# Altium schematic sheet 03_Power_Delivery.SchDoc
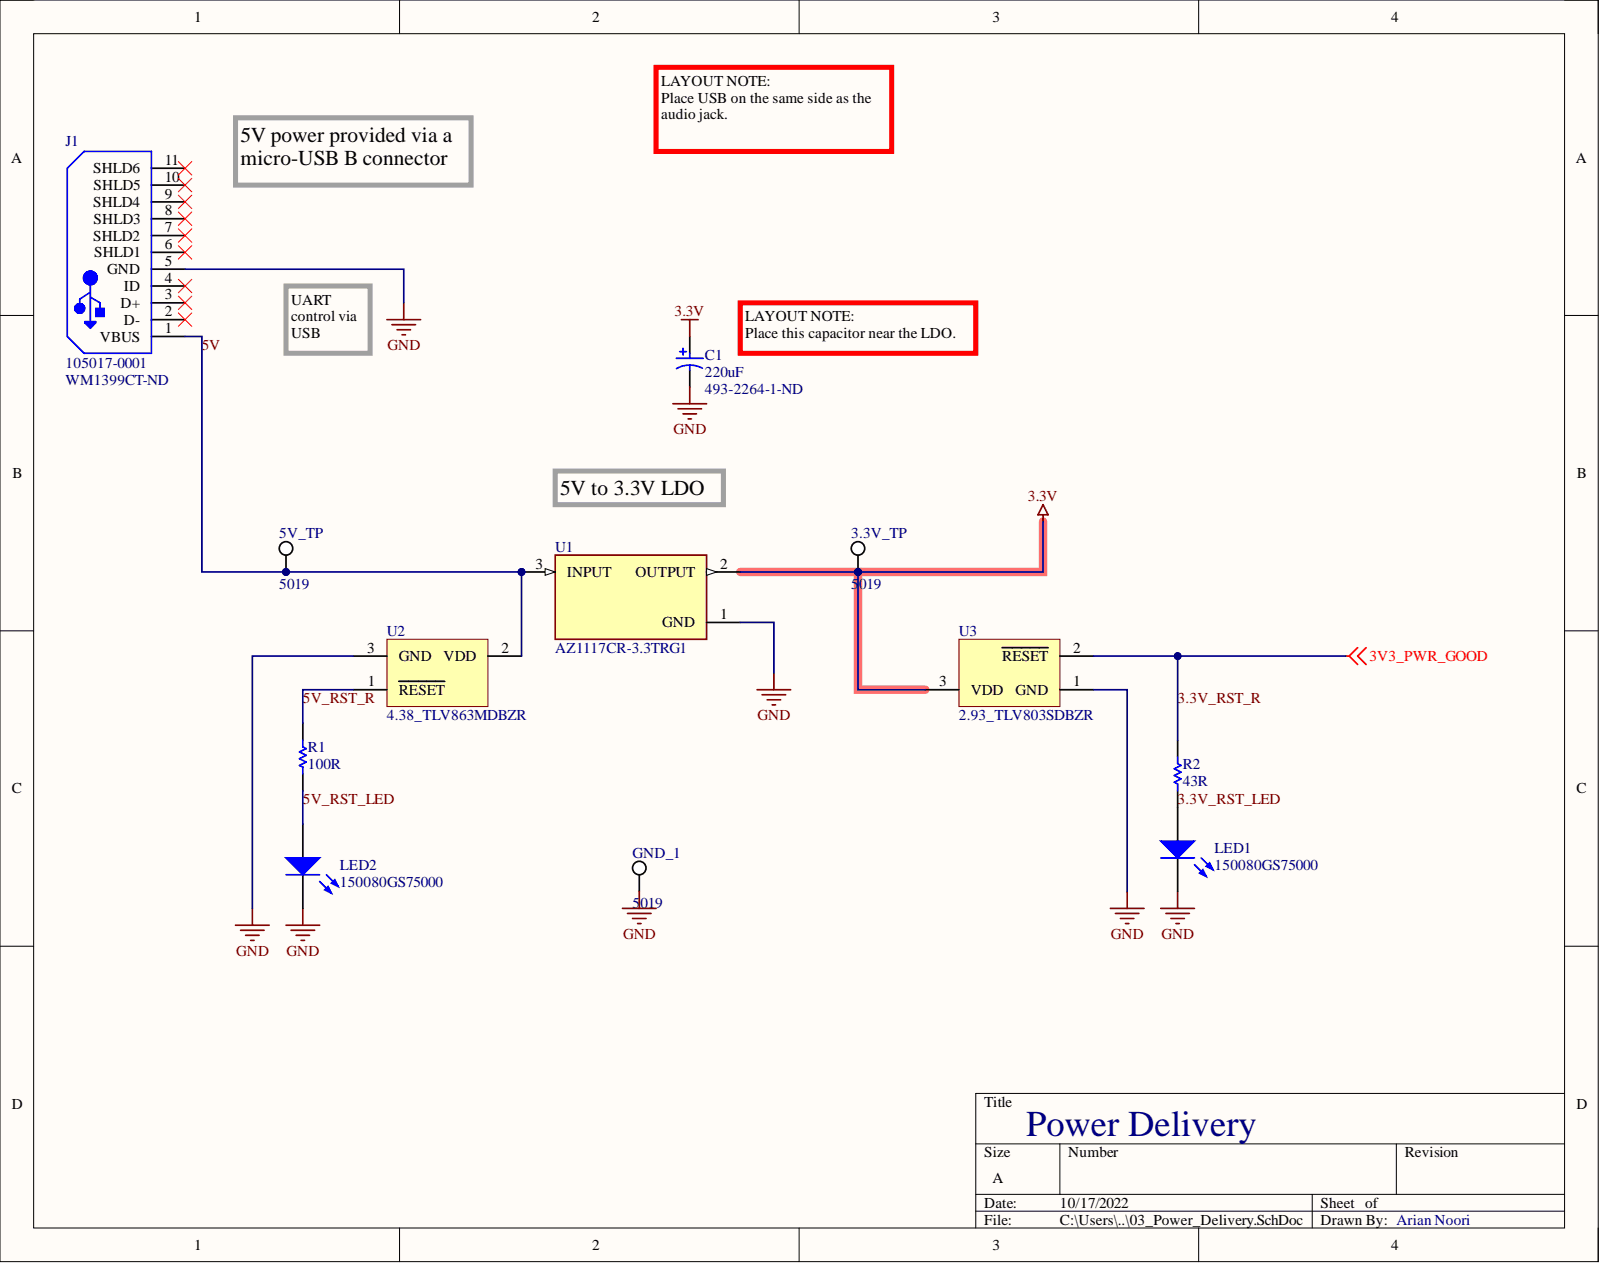
[diagram p0: 03_Power_Delivery.SchDoc]

## Parts
3.3V_TP  5019  [KSTN-5019_V]
5V_TP  5019  [KSTN-5019_V]
C1  220uF  Supplier Part Number 1: 493-2264-1-ND  [NIC-CAPA2-6.3X7.7_V]
GND_1  5019  [KSTN-5019_V]
J1  105017-0001  Supplier Part Number 1: WM1399CT-ND  [USB-MICRO-B_V]
LED1  150080GS75000  [0805_A]
LED2  150080GS75000  [0805_A]
R1  100R  [RESC2013X60X35ML10T20]
R2  43R  [RESC2013X60X35LL10T20]
U1  AZ1117CR-3.3TRG1  [FP-SOT89-MFG]
U2  4.38_TLV863MDBZR  [DBZ0003A_N]
U3  2.93_TLV803SDBZR  [DBZ0003A_M]

## Nets
3.3V: 3.3V_TP.1 C1.1 U1.2 U3.3
GND: C1.2 GND_1.1 J1.5(GND) LED1.1 LED2.1 U1.1 U2.3 U3.1
3.3V_RST_LED: LED1.2 R2.1
3.3V_RST_R: R2.2 U3.2
5V: 5V_TP.1 J1.1(VBUS) U1.3 U2.2
5V_RST_LED: LED2.2 R1.1
5V_RST_R: R1.2 U2.1

Not connected: J1 2(D-) 3(D+) 4(ID) 6(SHLD1) 7(SHLD2) 8(SHLD3) 9(SHLD4) 10(SHLD5) 11(SHLD6)

## Library data of the parts
3.3V_TP 5V_TP GND_1 = CMP-1672-00008-1: Test Point, 1 Position SMD, RoHS, Tape and Reel; Manufacturer: Keystone Electronics; Category: Connectors; Component Kind: Standard; ComponentLink1Description: Manufacturer URL; ComponentLink1URL: http://www.keyelco.com/; ComponentLink2Description: Datasheet; ComponentLink2URL: http://www.keyelco.com/product-pdf.cfm?p=1357; ComponentLink3Description: https://datasheet.ciiva.com/16318/m60-2p61-16318395.pdf?src-supplier=Future+Electronics; ComponentLink3URL: https://datasheet.ciiva.com/16318/m60-2p61-16318395.pdf?src-supplier=Future+Electronics; ComponentLink4Description: https://datasheet.ciiva.com/16885/70182998-16885945.pdf?src-supplier=Allied+Electronics; ComponentLink4URL: https://datasheet.ciiva.com/16885/70182998-16885945.pdf?src-supplier=Allied+Electronics; ComponentLink5Description: https://datasheet.ciiva.com/14848/m60-2p61-14848437.pdf?src-supplier=Digi-Key; ComponentLink5URL: https://datasheet.ciiva.com/14848/m60-2p61-14848437.pdf?src-supplier=Digi-Key; ComponentLink6Description: https://datasheet.ciiva.com/14848/m60-2p61-14848438.pdf?src-supplier=Digi-Key; ComponentLink6URL: https://datasheet.ciiva.com/14848/m60-2p61-14848438.pdf?src-supplier=Digi-Key; ComponentLink7Description: https://datasheet.ciiva.com/14848/m60-2p61-14848439.pdf?src-supplier=Digi-Key; ComponentLink7URL: https://datasheet.ciiva.com/14848/m60-2p61-14848439.pdf?src-supplier=Digi-Key; Contact Material: Bronze; Contact Plating: Silver; DatasheetVersion: 03/2014; Height: 0.09inch; Lead Free: Lead Free; Library Name: Altium Content Vault; Manufacturer Part Number: 5019; Material: Bronze; Mount: Surface Mount; Mounting Technology: Surface Mount; Number of Pins: 1; Package Quantity: 1000; PackageDescription: 1-Pin Surface Mount Device, Body 3.81 x 2.03 mm; PackageReference: 5019; Packaging: Tape and Reel; Pins: 1; Pricing 1: 1=0.34000, 25=0.27360, 100=0.22340, 250=0.20064, 500=0.19152 (USD); REACH SVHC: No SVHC; RoHS: Yes; RoHS Compliant: Yes; RoHSCompliant: True; Series: 5019; Stock 1: 1306897; Supplier 1: Digi-Key; Termination: SMD/SMT
C1 = CMP-0687-00060-1: Aluminum Electrolytic Capacitor, 220uF 16 V, UD Series 2000h 6.3 (Dia.) x 7.7mm; Manufacturer: Nichicon; Manufacturer Part Number: UUD1C221MCL1GS; Dielectric Material: Aluminium; Dissipation Factor: 0.2%; Impedance: 0.34 Ohm; Lead Diameter: 0.8mm; Leakage Current: 3uA; Life (Hours): 2000Hour; Packing: Dia382mm Reel; Rated Ripple Current (at 100kHz): 280 mA; Rated Voltage: 16 V; Ripple Current: 280mA; Tolerance: 20%; Tolerance Rated Capacitance: -20 to 20%; Voltage: 16V; Capacitance: 220uF; Case/Package: Radial; Category: Aluminum Electrolytic Capacitors; Component Kind: Standard; ComponentLink1Description: Manufacturer URL; ComponentLink1URL: http://www.nichicon.co.jp/; ComponentLink2Description: Datasheet; ComponentLink2URL: http://products.nichicon.co.jp/en/pdf/XJA043/e-ud.pdf; ComponentLink3Description: Package Specification; ComponentLink3URL: http://products.nichicon.co.jp/en/pdf/XJA043/e-ud.pdf; ComponentLink4Description: https://datasheet.ciiva.com/97/e-ud-97205.pdf?src-supplier=Digi-Key; ComponentLink4URL: https://datasheet.ciiva.com/97/e-ud-97205.pdf?src-supplier=Digi-Key; ComponentLink5Description: https://datasheet.ciiva.com/97/e-ud-97206.pdf?src-supplier=Digi-Key; ComponentLink5URL: https://datasheet.ciiva.com/97/e-ud-97206.pdf?src-supplier=Digi-Key; ComponentLink6Description: https://datasheet.ciiva.com/97/e-ud-97207.pdf?src-supplier=Digi-Key; ComponentLink6URL: https://datasheet.ciiva.com/97/e-ud-97207.pdf?src-supplier=Digi-Key; ComponentLink7Description: https://datasheet.ciiva.com/11685/e-ud-11685974.pdf?src-supplier=Avnet; ComponentLink7URL: https://datasheet.ciiva.com/11685/e-ud-11685974.pdf?src-supplier=Avnet; ComponentLink8Description: https://datasheet.ciiva.com/5361/e-ud-5361934.pdf?src-supplier=Mouser; ComponentLink8URL: https://datasheet.ciiva.com/5361/e-ud-5361934.pdf?src-supplier=Mouser; ComponentLink9Description: https://datasheet.ciiva.com/16373/e-ud-16373647.pdf?src-supplier=Future+Electronics; ComponentLink9URL: https://datasheet.ciiva.com/16373/e-ud-16373647.pdf?src-supplier=Future+Electronics; ComponentLink10Description: https://datasheet.ciiva.com/7781/e-ud-7781693.pdf?src-supplier=Element14; ComponentLink10URL: https://datasheet.ciiva.com/7781/e-ud-7781693.pdf?src-supplier=Element14; Construction: Cylindrical; DatasheetVersion: 08/2012; Diameter: 6.3 mm; Endurance Time: 2000 h; Height: 7.7mm; Height - Seated (Max): 0.303inch; Lead Free: Lead Free; Lead Pitch: 2.2mm; Length: 7.7 mm; Library Name: Altium Content Vault; Max Operating Temperature: 105°C; Min Operating Temperature: -55°C; Mounting Technology: Surface Mount; Number of Pins: 2; Package Quantity: 1; PackageDescription: 2-Pin Surface Mount Device, Diameter 6.3 mm, Height 7.7 mm, Pitch 5.4 mm; PackageReference: CAPAE-D6.3H7.7; Packaging: Tape and Reel; PartNumber: UUD1C221MCL1GS; Pricing 1: 1=0.46000, 10=0.32000, 50=0.22420, 100=0.19220 (USD); Radiation Hardening: No; Rated Capacitance: 220 uF; REACH SVHC: No SVHC; Ripple Current (AC): 280mA; RoHS: TRUE; RoHS Compliant: Yes; Series: UD; Stock 1: 25833; Supplier 1: Digi-Key; Termination: SMD/SMT; Voltage Rating: 16V; Voltage Rating (DC): 16V
J1 = CMP-2000-05827-1: Micro-USB B Receptacle, Right Angle, Bottom Mount, Surface Mount, with Solder Tabs, -30 to 85 degC, 5-Pin USB, RoHS, Tape and Reel; Manufacturer: Molex; 3D Model: 105017-0001.stp; Catalog Drawings: 1050170001; Circuits: 5; Connector Type: USB-microB; Current Rating: 1.8A; Featured Product: Micro-USBConnectorsUSBConnectors; Mating Cycles: 10000; Mating Products: WM17145-ND-CABLEMICROUSBBTOSTDA1.0MWM17147-ND-CABLEMICROUSBBTOSTDA2.0MWM17146-ND-CABLEMICROUSBBTOSTDA1.5M; Max Voltage Rating (AC): 30V; Number of Ports: 1; Online Catalog: Molex-MicroUSB; Other Names: WM1399DKR; Package Version: Rev. F, 12/2012; PCN Assembly/Origin: ResinSupplier21/Oct/2015; Pitch: 0.65mm; Shielding: Shielded; USB Standard: USB 2.0; Category: Connectors,Interconnects; Component Kind: Standard; ComponentLink1Description: https://datasheet.ciiva.com/30165/235271050170001-io-nectors-30165183.pdf?src-supplier=Onlinecomponents.com; ComponentLink1URL: https://datasheet.ciiva.com/30165/235271050170001-io-nectors-30165183.pdf?src-supplier=Onlinecomponents.com; ComponentLink2Description: https://datasheet.ciiva.com/8015/1631959-8015288.pdf?src-supplier=Element14; ComponentLink2URL: https://datasheet.ciiva.com/8015/1631959-8015288.pdf?src-supplier=Element14; ComponentLink3Description: https://datasheet.ciiva.com/22654/1688140-22654924.pdf?src-supplier=Element14; ComponentLink3URL: https://datasheet.ciiva.com/22654/1688140-22654924.pdf?src-supplier=Element14; ComponentLink4Description: https://datasheet.ciiva.com/3094/1050170001-io-connectors-3094416.pdf?src-supplier=Avnet; ComponentLink4URL: https://datasheet.ciiva.com/3094/1050170001-io-connectors-3094416.pdf?src-supplier=Avnet; ComponentLink5Description: https://datasheet.ciiva.com/4598/1050170001-io-connectors-152207-4598759.pdf?src-supplier=Mouser; ComponentLink5URL: https://datasheet.ciiva.com/4598/1050170001-io-connectors-152207-4598759.pdf?src-supplier=Mouser; ComponentLink6Description: https://datasheet.ciiva.com/851/1050170001-sd-851276.pdf?src-supplier=Digi-Key; ComponentLink6URL: https://datasheet.ciiva.com/851/1050170001-sd-851276.pdf?src-supplier=Digi-Key; ComponentLink7Description: https://datasheet.ciiva.com/851/1050170001-sd-851277.pdf?src-supplier=Digi-Key; ComponentLink7URL: https://datasheet.ciiva.com/851/1050170001-sd-851277.pdf?src-supplier=Digi-Key; ComponentLink8Description: https://datasheet.ciiva.com/851/1050170001-sd-851278.pdf?src-supplier=Digi-Key; ComponentLink8URL: https://datasheet.ciiva.com/851/1050170001-sd-851278.pdf?src-supplier=Digi-Key; ComponentLink9Description: https://datasheet.ciiva.com/16668/1050170001-io-connectors-16668598.pdf?; ComponentLink9URL: https://datasheet.ciiva.com/16668/1050170001-io-connectors-16668598.pdf?; Contact Material: Copper Alloy,Copper; Contact Plating: Gold; Datasheet URL: http://www.molex.com/elqNow/elqRedir.htm?ref=http://www.molex.com/webdocs/datasheets/pdf/en-us/1050170001_IO_CONNECTORS.pdf; Datasheet Version: 03/2016; Depth: 7.5mm; Family: USB,DVI,HDMIConnectors; Features: Shielded,SolderRetention; Flammability Rating: UL94 V-0; Gender: Receptacle; Halogen Free: Low Halogen; Housing Material: Polymer; Ingress Protection: -; Insulation Color: Black; Length: 8.2mm; Library Name: Altium Content Vault; Manufacturer Part Number: 1050170001; Manufacturer URL: http://www.molex.com/; Max Operating Temperature: 85°C; Min Operating Temperature: -30°C; Mount: Surface Mount,Through Hole,Horizontal; Mounting Technology: SurfaceMount; Mounting Type: BoardCutout,SurfaceMount,RightAngle; Number of Circuits: 5; Number of Contacts: 5; Number of Positions: 5; Number of Terminals/Pins: 5; Orientation: Right Angle; Package Description: 5-Leads USB, Micro B, Body 7.5 x 5 mm, Pitch 0.65 mm; Package Quantity: 2000; Package Reference: MICRO-B; Package URL: http://www.molex.com/pdm_docs/sd/1050170001_sd.pdf; Packaging: Digi-Reel®; Pricing 1: 1=1.01000, 10=0.84000, 100=0.69990, 1000=0.55994 (USD); Radiation Hardening: No; REACH SVHC: Unknown; RoHS: Yes; RoHS Compliant: Yes; RoHS Information: 1050170001CertofCompliance; Series: -; Specifications: -; Standard Package: 1; Stock 1: 49105; Supplier 1: Digi-Key; Termination: Solder; Voltage - Max: 30V; Voltage Rating: 30V; Voltage Rating (AC): 30V
LED1 LED2 = CMP-1426-00007-1: SMD mono-color Chip LED, WL-SMCW, Green; Manufacturer: Wuerth Elektronik; Manufacturer 1: Wurth Electronics; Dominant Wavelength: 520nm; Forward Current: 30mA; Forward Voltage: 3.2V; Lens Style: Clear,Rectangular; Luminous Intensity: 450mcd; Test Current: 20mA; Viewing Angle: 140°; Case Code (Imperial): 0805; Case Code (Metric): 2012; Case/Package: 0805; Category: LEDs; Color: Green; Component Kind: Standard; ComponentLink1Description: Manufacturer URL; ComponentLink1URL: http://www.we-online.com; ComponentLink2Description: Datasheet; ComponentLink2URL: http://katalog.we-online.com/led/datasheet/150080GS75000.pdf; ComponentLink3Description: https://datasheet.ciiva.com/29563/150080gs75000-29563008.pdf?src-supplier=Digi-Key; ComponentLink3URL: https://datasheet.ciiva.com/29563/150080gs75000-29563008.pdf?src-supplier=Digi-Key; ComponentLink4Description: https://datasheet.ciiva.com/29279/759434-da-01-en-chipled-0805-w-kl-gruen-150080gs75000-29279039.pdf?src-supplier=Conrad; ComponentLink4URL: https://datasheet.ciiva.com/29279/759434-da-01-en-chipled-0805-w-kl-gruen-150080gs75000-29279039.pdf?src-supplier=Conrad; Height: 0.7mm; Length: 2mm; Library Name: Altium Content Vault; Manufacturer Part Number 1: 150080GS75000; Max Operating Temperature: 85°C; Min Operating Temperature: -40°C; Mount: Surface Mount; Package Quantity: 4000; PackageReference: 0805_A; Packaging: Cut Tape; PartNumber: 150080GS75000; Pricing 1: 1=0.19000, 50=0.17600, 100=0.15100, 500=0.13100 (USD); REACH SVHC: Unknown; RoHS: Yes; RoHS Compliant: Yes; Stock 1: 95801; Supplier 1: Digi-Key; Supplier Part Number 1: 732-4983-1-ND; Wavelength: 520nm; Width: 1.25mm
R1 = CMP-2100-03669-1: Chip Resistor, 100 Ohm, +/-1%, 0.125 W, -55 to 155 degC, 0805 (2012 Metric), RoHS, Tape and Reel; Manufacturer: Yageo; Manufacturer Part Number: RC0805FR-07100RL; Application(s): HIGH RELIABILITY; Max Power Dissipation: 125mW; Resistance: 100Ohm; Temperature Coefficient: 100ppm/degreesC; Tolerance: 1%; Voltage Rating: 150V; Case Code (Imperial): 0805; Case Code (Metric): 2012; Case/Package: 0805; Category: Chip SMD Resistors; Component Kind: Standard; ComponentLink1Description: https://datasheet.ciiva.com/11808/0900766b8106beab-11808877.pdf?src-supplier=RSComponents; ComponentLink1URL: https://datasheet.ciiva.com/11808/0900766b8106beab-11808877.pdf?src-supplier=RSComponents; ComponentLink2Description: https://datasheet.ciiva.com/7941/1697719-7941680.pdf?src-supplier=Element14; ComponentLink2URL: https://datasheet.ciiva.com/7941/1697719-7941680.pdf?src-supplier=Element14; ComponentLink3Description: https://datasheet.ciiva.com/7941/1686128-7941679.pdf?src-supplier=Element14; ComponentLink3URL: https://datasheet.ciiva.com/7941/1686128-7941679.pdf?src-supplier=Element14; ComponentLink4Description: https://datasheet.ciiva.com/7660/38627-7660797.pdf?src-supplier=Element14; ComponentLink4URL: https://datasheet.ciiva.com/7660/38627-7660797.pdf?src-supplier=Element14; ComponentLink5Description: https://datasheet.ciiva.com/22747/444164-22747535.pdf?src-supplier=Element14; ComponentLink5URL: https://datasheet.ciiva.com/22747/444164-22747535.pdf?src-supplier=Element14; ComponentLink6Description: https://datasheet.ciiva.com/46447/444164-46447562.pdf?src-supplier=Element14; ComponentLink6URL: https://datasheet.ciiva.com/46447/444164-46447562.pdf?src-supplier=Element14; ComponentLink7Description: https://datasheet.ciiva.com/2456/pyu-r-int-thick-7-2456988.pdf?src-supplier=Digi-Key; ComponentLink7URL: https://datasheet.ciiva.com/2456/pyu-r-int-thick-7-2456988.pdf?src-supplier=Digi-Key; ComponentLink8Description: https://datasheet.ciiva.com/2456/pyu-r-int-thick-7-2456989.pdf?src-supplier=Digi-Key; ComponentLink8URL: https://datasheet.ciiva.com/2456/pyu-r-int-thick-7-2456989.pdf?src-supplier=Digi-Key; ComponentLink9Description: https://datasheet.ciiva.com/2456/pyu-r-int-thick-7-2456987.pdf?src-supplier=Digi-Key; ComponentLink9URL: https://datasheet.ciiva.com/2456/pyu-r-int-thick-7-2456987.pdf?src-supplier=Digi-Key; ComponentLink10Description: https://datasheet.ciiva.com/17082/rc0805-17082697.pdf?src-supplier=Future+Electronics; ComponentLink10URL: https://datasheet.ciiva.com/17082/rc0805-17082697.pdf?src-supplier=Future+Electronics; Composition: ThickFilm; Datasheet URL: http://www.yageo.com/NewPortal/yageodocoutput?fileName=/pdf/R-Chip/PYu-RC_Group_51_RoHS_L_5.pdf; Datasheet Version: Rev. 5, 10/2016; Depth: 1.25mm; Features: Moisture Resistant; Height: 0.024inch; Lead Free: LeadFree; Lead Pitch: 0.4mm; Length: 2mm; Library Name: Altium Content Vault; Manufacturer URL: http://www.yageo.com/; Max Operating Temperature: 155degC; Military Standard: Not; Min Operating Temperature: -55degC; Number of Pins: 2; Number of Terminations: 2; Package Description: 2-Pin Surface Mounted Device, Body 2 x 1.25 mm; Package Quantity: 1; Package Reference: 0805; Packaging: TapeandReel; Power Rating: 125mW; Pricing 1: 1=0.10000, 10=0.03700, 100=0.01510, 1000=0.00680, 2500=0.00590 (USD); REACH SVHC: Unknown; Resistor Type: Thick Film; RoHS: Yes; RoHS Compliant: Yes; RoHSCompliant: true; Series: RC; Stock 1: 3207618; Supplier 1: Digi-Key; Technology: THICK FILM; Termination: SMD/SMT; Type: General Purpose; Voltage Rating (DC): 150V; Weight: 0.000917oz; Width: 0.049inch
R2 = CMP-2001-01384-1: RES 43 OHM 1/8W .5% SMD 0805; Manufacturer: Yageo; Manufacturer Part Number: RC0805JR-0743RL; Max Power Dissipation: 125mW; Temperature Coefficient: 100ppm/°C; Tolerance: 5%; Voltage Rating: 150V; Case Code (Imperial): 0805; Case Code (Metric): 2012; Case/Package: 0805; Category: Chip SMD Resistors; Component Kind: Standard; ComponentLink1Description: https://datasheet.ciiva.com/12560/rc0805-257173-12560726.pdf?src-supplier=Mouser; ComponentLink1URL: https://datasheet.ciiva.com/12560/rc0805-257173-12560726.pdf?src-supplier=Mouser; ComponentLink2Description: https://datasheet.ciiva.com/16745/rc0805-16745692.pdf?src-supplier=Future+Electronics; ComponentLink2URL: https://datasheet.ciiva.com/16745/rc0805-16745692.pdf?src-supplier=Future+Electronics; Construction: Rectangular; Contact Plating: Tin,Matte; Depth: 1.25mm; Features: Moisture Resistant; Height: 0.5mm; Lead Free: Lead Free; Length: 2mm; Library Name: Altium Content Vault; Max Operating Temperature: 155°C; Military Standard: Not; Min Operating Temperature: -55°C; Number of Terminations: 2; Packaging: Tape and Reel; Part Number: RC0805JR-0743RL; Power Rating: 125mW; Pricing 1: 1=0.10000, 10=0.03200, 100=0.01300, 1000=0.00584, 2500=0.00507 (USD); Radiation Hardening: No; Resistance: 43R; Resistor Type: Thick Film; RoHS: Yes; RoHS Compliant: Yes; Series: RC; Size Code: 0805; Stock 1: 8138; Supplier 1: Digi-Key; Termination: SMD/SMT; Voltage Rating (DC): 150V; Width: 1.25mm
U1 = CMP-12756-000007-1: IC REG LINEAR 3.3V 800MA SOT89; Manufacturer: Diodes Inc.; Accuracy: 1%; Dropout Voltage: 1.2V; Max Input Voltage: 15V; Max Junction Temp: +125°C; Max Output Current: 1.35A; Min Current Limit: 1A; Nominal Supply Current: 4mA; Number Of Outputs: 1; Output Type: Fixed; Polarity: Positive; Power Supply Rejection Ratio (PSRR): 70dB; Reference Voltage: 1.262V; Accuracy Percentage: 1%; Automotive: No; Case/Package: SOT-89; Category: IC; Component Kind: Standard; ComponentLink1Description: https://datasheet.ciiva.com/29568/az1117c-29568862.pdf?src-supplier=Digi-Key; ComponentLink1URL: https://datasheet.ciiva.com/29568/az1117c-29568862.pdf?src-supplier=Digi-Key; ComponentLink2Description: Datasheet; ComponentLink2URL: https://www.diodes.com/assets/Datasheets/AZ1117C.pdf; ComponentLink3Description: https://datasheet.ciiva.com/30016/az1117c-30016678.pdf?src-supplier=Avnet; ComponentLink3URL: https://datasheet.ciiva.com/30016/az1117c-30016678.pdf?src-supplier=Avnet; Device Class L1: Integrated Circuits (ICs); Device Class L2: Power Management ICs; Device Class L3: Voltage Regulators - Linear; Digikey Description: IC REG LINEAR 3.3V 800MA SOT89; Footprint Url: https://www.diodes.com/assets/Package-Files/SOT89.pdf; Height: 1.6mm; Lead Free: Yes; Length: 4.6mm; Library Name: Altium Content Vault; Manufacturer Part Number: AZ1117CR-3.3TRG1; Max Operating Temperature: 125°C; Max Supply Voltage: 15V; Min Operating Temperature: -20°C; Mount: Surface Mount; Mouser Description: DiodesZetex AZ1117CR-3.3TRG1, LDO Regulator, 800mA, 3.3 V, ±1% 3-Pin, SOT-89; Number of Pins: 3; Number of Regulators: 1; Octopart: https://octopart.com/az1117cr-3.3trg1-diodes+inc.-30954805; Output Current: 1.35A; Output Voltage: 3.3V; Package: SOT89; Package Quantity: 1000; Packaging: Tape and Reel; Pricing 1: 1=0.44000, 10=0.33300, 25=0.30040, 100=0.20740, 250=0.17468, 500=0.14194 (USD); Quiescent Current: 4mA; RoHS: TRUE; Stock 1: 15482; Supplier 1: Digi-Key; Temperature: -20°C to +125°C; Temperature Range High: +125°C; Temperature Range Low: -20°C; Weight: 0.004603oz; Width: 2.6mm
U2 = CMP-0332-00606-2: Voltage Supervisor with Active-Low, Open-Drain Reset, 1 Supply Monitored, -40 to 125 degC, 3-pin SOT-23 (DBZ), Green (RoHS & no Sb/Br); Manufacturer: Texas Instruments; # of Supplies Monitored: 1; Iq(Typ)(uA): 9; Max Reset Threshold Voltage: 4.48V; Max Supply Current: 30uA; Max Supply Voltage: 6V; Min Reset Threshold Voltage: 4.28V; Min Supply Voltage: 1.1V; Operating Supply Current: 20uA; Quiescent Current: 9uA; Rating: Catalog; Reset: Active Low; Reset Threshold Accuracy(%): 2; Reset Timeout: 120ms; SubFamily: Supervisor and Reset IC; Threshold Voltage: 4.38V; Threshold Voltage 1 (typ)(Typ)(V): 4.38; Time Delay(ms): 200; VCC(Max)(V): 6; VCC(Min)(V): 1.1; Case/Package: SOT-23-3; Category: Voltage Supervisors; Code_JEDEC: TO-236-AB; Component Kind: Standard; ComponentLink1Description: Datasheet; ComponentLink1URL: http://www.ti.com/general/docs/lit/getliterature.tsp?genericPartNumber=TLV863M&fileType=pdf; ComponentLink2Description: https://datasheet.ciiva.com/14203/tlv863m-14203620.pdf?src-supplier=Avnet; ComponentLink2URL: https://datasheet.ciiva.com/14203/tlv863m-14203620.pdf?src-supplier=Avnet; ComponentLink3Description: https://datasheet.ciiva.com/1979/tlv863m-1979819.pdf?src-supplier=Digi-Key; ComponentLink3URL: https://datasheet.ciiva.com/1979/tlv863m-1979819.pdf?src-supplier=Digi-Key; ComponentLink4Description: https://datasheet.ciiva.com/1979/tlv863m-1979818.pdf?src-supplier=Digi-Key; ComponentLink4URL: https://datasheet.ciiva.com/1979/tlv863m-1979818.pdf?src-supplier=Digi-Key; ComponentLink5Description: https://datasheet.ciiva.com/1979/tlv863m-1979820.pdf?src-supplier=Digi-Key; ComponentLink5URL: https://datasheet.ciiva.com/1979/tlv863m-1979820.pdf?src-supplier=Digi-Key; DatasheetVersion: SBVS157B; Lead Free: Lead Free; Library Name: Altium Content Vault; Manufacturer Part Number: TLV863MDBZR; Max Operating Temperature: 125°C; Min Operating Temperature: -40°C; Mounting Technology: Surface Mount; Nominal Supply Current: 30uA; Number of Elements: 1; Number of Pins: 3; Number of Voltages Monitored: 1; Output Driver Type / Reset Output: Active-low, Open-drain; Package Quantity: 1; PackageDescription: 3-Pin Plastic Small-Outline Package, 0.95 mm Pitch, 2.4 mm Lead Span; PackageReference: DBZ0003A; PackageVersion: revB, Apr-2005; Packaging: Tape and Reel; PartNumber: TLV863MDBZR; Pricing 1: 1=0.90000, 10=0.80100, 25=0.76000, 100=0.62410, 250=0.58344, 500=0.51558, 1000=0.40960 (USD); Radiation Hardening: No; RoHS: Yes; RoHS Compliant: Yes; Series: -; Stock 1: 1146; Supplier 1: Digi-Key; Supply Current: 30uA; Watchdog Timer: No
U3 = CMP-0332-00609-2: Voltage Supervisor with Active-Low, Open Drain Reset, 1 Supply Monitored, -40 to 125 degC, 3-pin SOT-23 (DBZ), Green (RoHS & no Sb/Br); Manufacturer: Texas Instruments; # of Supplies Monitored: 1; Iq(Typ)(uA): 9; Max Reset Threshold Voltage: 2.99V; Max Supply Current: 30uA; Max Supply Voltage: 6V; Min Reset Threshold Voltage: 2.87V; Min Supply Voltage: 1.1V; Operating Supply Current: 20uA; Output Driver Type / Reset Output: Active-low, Open-drain; Quiescent Current: 9uA; Rating: Catalog; Reset: Active Low; Reset Threshold Accuracy(%): 2; Reset Timeout: 120ms; SubFamily: Supervisor and Reset IC; Threshold Voltage: 2.93V; Threshold Voltage 1 (typ)(Typ)(V): 2.93; Time Delay(ms): 200; VCC(Max)(V): 6; VCC(Min)(V): 1.1; Case/Package: SOT-23; Category: Voltage Supervisors; Code_JEDEC: TO-236-AB; Component Kind: Standard; ComponentLink1Description: Datasheet; ComponentLink1URL: http://www.ti.com/general/docs/lit/getliterature.tsp?genericPartNumber=TLV803S&fileType=pdf; ComponentLink2Description: https://datasheet.ciiva.com/15105/getdatasheetpartid-544055-15105605.pdf?src-supplier=Verical; ComponentLink2URL: https://datasheet.ciiva.com/15105/getdatasheetpartid-544055-15105605.pdf?src-supplier=Verical; ComponentLink3Description: https://datasheet.ciiva.com/1979/tlv803m-1979838.pdf?src-supplier=Digi-Key; ComponentLink3URL: https://datasheet.ciiva.com/1979/tlv803m-1979838.pdf?src-supplier=Digi-Key; ComponentLink4Description: https://datasheet.ciiva.com/1979/tlv803m-1979837.pdf?src-supplier=Digi-Key; ComponentLink4URL: https://datasheet.ciiva.com/1979/tlv803m-1979837.pdf?src-supplier=Digi-Key; ComponentLink5Description: https://datasheet.ciiva.com/1979/tlv803m-1979839.pdf?src-supplier=Digi-Key; ComponentLink5URL: https://datasheet.ciiva.com/1979/tlv803m-1979839.pdf?src-supplier=Digi-Key; ComponentLink6Description: https://datasheet.ciiva.com/14203/tlv863m-14203548.pdf?src-supplier=Avnet; ComponentLink6URL: https://datasheet.ciiva.com/14203/tlv863m-14203548.pdf?src-supplier=Avnet; DatasheetVersion: SBVS157B; Lead Free: Lead Free; Library Name: Altium Content Vault; Manufacturer Part Number: TLV803SDBZR; Max Operating Temperature: 125°C; Min Operating Temperature: -40°C; Mount: Surface Mount; Mounting Technology: Surface Mount; Nominal Supply Current: 30uA; Number of Elements: 1; Number of Pins: 3; Number of Voltages Monitored: 1; Package Quantity: 1; PackageDescription: 3-Pin Plastic Small-Outline Package, 0.95 mm Pitch, 2.4 mm Lead Span; PackageReference: DBZ0003A; PackageVersion: revB, Apr-2005; Packaging: Tape and Reel; PartNumber: TLV803SDBZR; Pricing 1: 1=0.95000, 10=0.83600, 25=0.78520, 100=0.64100, 250=0.59536, 500=0.50668, 1000=0.40534 (USD); Radiation Hardening: No; REACH SVHC: No SVHC; RoHS: Yes; RoHS Compliant: Yes; Series: -; Stock 1: 24687; Supplier 1: Digi-Key; Supply Current: 30uA; Type: Simple Reset/Power-On Reset; Watchdog Timer: No
